# Altium schematic sheet 01-Lora-E5.SchDoc
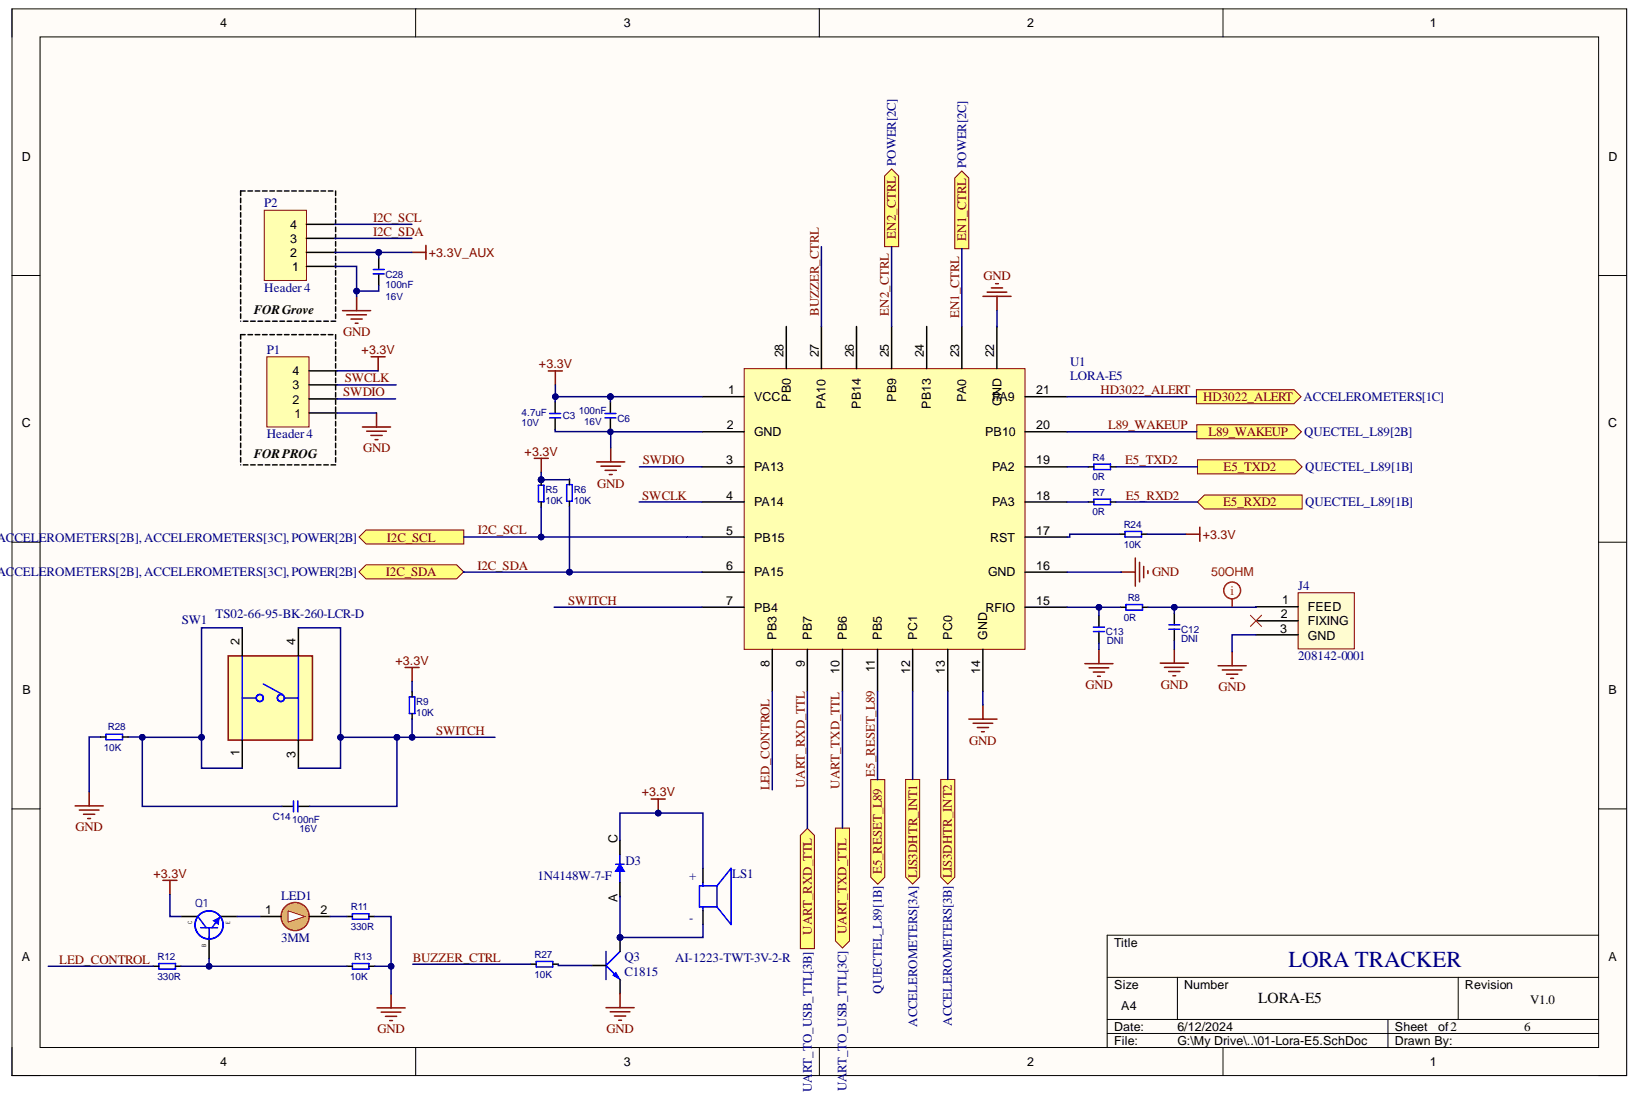
[diagram p0: 01-Lora-E5.SchDoc]

## Parts
C3  4.7uF  Rating: 10V  [T_CAPC0603X33N]
C6  100nF  Rating: 16V  [CAPC1005X60N]
C12  DNI  [CAPC1005X60N]
C13  DNI  [CAPC1005X60N]
C14  100nF  Rating: 16V  [CAPC1005X60N]
C28  100nF  Rating: 16V  [CAPC1005X60N]
D3  1N4148W-7-F  [SOD123]
J4  208142-0001  [2081420001]
LED1  3MM  [LED_GREEN_3MM]
LS1  AI-1223-TWT-3V-2-R  [XDCR_AI-1223-TWT-3V-2-R]
P1  Header 4  [HDR1X4]
P2  Header 4  [HDR1X4]
Q1  ''  [SOT95P240X120-3N]  (value not drawn)
Q3  C1815  [SOT23B]
R4  0R  [RESC1005X40N]
R5  10K  [RESC1005X40N]
R6  10K  [RESC1005X40N]
R7  0R  [RESC1005X40N]
R8  0R  [RESC1005X40N]
R9  10K  [RESC1005X40N]
R11  330R  [RESC1005X40N]
R12  330R  [RESC1005X40N]
R13  10K  [RESC1005X40N]
R24  10K  [RESC1005X40N]
R27  10K  [RESC1005X40N]
R28  10K  [RESC1005X40N]
SW1  TS02-66-95-BK-260-LCR-D  [SW_TS02-66-95-BK-260-LCR-D]
U1  LORA-E5  [LORA-E5]

## Ports
E5_RESET_L89, E5_RXD2, E5_TXD2, EN1_CTRL, EN2_CTRL, HD3022_ALERT, I2C_SCL, I2C_SDA, L89_WAKEUP, LIS3DHTR_INT1, LIS3DHTR_INT2, UART_RXD_TTL, UART_TXD_TTL

## Nets
+3.3V: C3.1 C6.1 D3.C LS1.P P1.4 Q1.3 R5.2 R6.2 R9.2 R24.1 U1.1(VCC)
+3.3V_AUX: C28.1 P2.2
GND: C3.2 C6.2 C12.2 C13.2 C28.2 J4.3 P1.1 P2.1 Q3.1 R11.2 R13.2 R28.1 U1.2(GND) U1.14(GND) U1.16(GND) U1.22(GND)
BUZZER_CTRL: R27.2 U1.27(PA10)
E5_RESET_L89: U1.11(PB5) ; port E5_RESET_L89
E5_RXD2: R7.1 ; port E5_RXD2
E5_TXD2: R4.1 ; port E5_TXD2
EN1_CTRL: U1.23(PA0) ; port EN1_CTRL
EN2_CTRL: U1.25(PB9) ; port EN2_CTRL
HD3022_ALERT: U1.21(PA9) ; port HD3022_ALERT
I2C_SCL: P2.4 R5.1 U1.5(PB15) ; port I2C_SCL
I2C_SDA: P2.3 R6.1 U1.6(PA15) ; port I2C_SDA
L89_WAKEUP: U1.20(PB10) ; port L89_WAKEUP
LED_CONTROL: R12.1 U1.8(PB3)
LIS3DHTR_INT1: U1.12(PC1) ; port LIS3DHTR_INT1
LIS3DHTR_INT2: U1.13(PC0) ; port LIS3DHTR_INT2
SWCLK: P1.3 U1.4(PA14)
SWDIO: P1.2 U1.3(PA13)
SWITCH: C14.1 R9.1 SW1.3 SW1.4 U1.7(PB4)
UART_RXD_TTL: U1.9(PB7) ; port UART_RXD_TTL
UART_TXD_TTL: U1.10(PB6) ; port UART_TXD_TTL
NetC12_1: C12.1 J4.1 R8.2
NetC13_1: C13.1 R8.1 U1.15(RFIO)
NetC14_2: C14.2 R28.2 SW1.1 SW1.2
NetD3_A: D3.A LS1.N Q3.2
NetLED1_1: LED1.1 Q1.2
NetLED1_2: LED1.2 R11.1
NetQ1_1: Q1.1 R12.2 R13.1
NetQ3_3: Q3.3 R27.1
NetR4_2: R4.2 U1.19(PA2)
NetR7_2: R7.2 U1.18(PA3)
NetR24_2: R24.2 U1.17(RST)

Not connected: J4 2; U1 24(PB13) 26(PB14) 28(PB0)

## Library data of the parts
C3 = C-X5R-4.7uF-10V-10%-0603: Capacitor Ceramic X5R 4.7uF 10V 10% 0603; Manufacturer Name: Generic; MP: CC0603KRX5R6BB475; Component Kind: Standard; Tolerance: 10%; Type: X5R; Value: 4.7uF; Library Name: Iris.SchLib
C6 C12-C14 C28 = C-X7R-100nF-16V-10%-0603: CAP CER 0.1UF 16V X7R 0402; MP: CC0402KRX7R7BB104; Tolerance: 10%; Component Kind: Standard; Type: X7R; Library Name: Evaluation Board.SchLib
  C6 C14 C28: Value: 100nF
  C12 C13: Value: DNI
D3 = 1N4148W-7-F: Diode Standard 100 V 300mA (DC) Surface Mount SOD-123; MF: Diodes Inc.; Availability: In Stock; Check_prices: https://www.snapeda.com/parts/1N4148W-7-F/Diodes+Inc./view-part/?ref=eda; Component Kind: Standard; Library Name: 1N4148W-7-F.SchLib; MP: 1N4148W-7-F; Package: SOD-123 Fairchild Semiconductor; Price: None; Purchase-URL: https://pricing.snapeda.com/search/part/1N4148W-7-F/?ref=eda; SnapEDA_Link: https://www.snapeda.com/parts/1N4148W-7-F/Diodes+Inc./view-part/?ref=snap
J4 = Component_1: Antennas ISM 868/915MHz Ceramic Antenna SMT; MF: MOLEX; Component Kind: Standard; Library Name: 208142-0001.SchLib; MP: 208142-0001
LED1 = LED 3mm: LED 3mm GREEN; MF: LUMEX; MP: SSL-LX30FT4GD; Component Kind: Standard; Library Name: My Library.SchLib
LS1 = AI-1223-TWT-3V-2-R: AUDIO INDICATOR 5VDC 30MA 85DB; MF: {'manufacturer': u'PUI Audio, Inc.'}; Availability: Unavailable; Component Kind: Standard; Library Name: AI-1223-TWT-3V-2-R.SchLib; MP: AI-1223-TWT-3V-2-R; Package: None; Price: None
P1 P2 = Header 4: Header, 4-Pin; MF: MOLEX; Component Kind: Standard; LatestRevisionDate: 17-Jul-2002; LatestRevisionNote: Re-released for DXP Platform.; Library Name: My Library.SchLib; MP: 22-28-4042; Signal Integrity: Connector; Publisher: Altium Limited
Q1 = MMBT3904LT1G: BJT NPN,40V,200MA,SOT23-3,SMD; MF: ON Semiconductor; No: 3; BJT Type: NPN; Component Kind: Standard; Database Table Name: BJT; Ic (Max): 200mA; Library Name: Component Library.DbLib; Mounting Type: Surface Mount (SMD); MP: MMBT3904LT1G; Package: SOT23-3 (SOT23, TO236AB, SOT346, SC59A, TO236AA, SMT3); Part Number: MMBT3904LT1G; Vce (Max): 40V
Q3 = C1815: NPN 600mW, ECB; MP: BC850C; Component Kind: Standard; Library Name: Cty1.SchLib; Value: C1815
R4 R7 R8 = R-22R-63mW-5%-0402: RES 0 OHM JUMPER 1/16W 0402; MP: RC0402JR-070RL; Rating: 63mW; Tolerance: 5%; Component Kind: Standard; Value: 0R; Library Name: Iris.SchLib
R5 R6 R9 R13 R24 R27 R28 = R-22R-63mW-5%-0402: Resistor 10K ohm 63mW 5% 0402; MP: RC0402JR-0710KL; Component Kind: Standard; Rating: 63mW; Tolerance: 5%; Value: 10K; Library Name: Iris.SchLib
R11 R12 = R-10KR-63mW-5%-0603: RES 330 OHM 5% 1/16W 0402; MP: RC0402JR-13330RL; Rating: 63mW; Component Kind: Standard; Tolerance: 5%; Value: 330R; Library Name: Evaluation Board.SchLib
SW1 = TS02-66-95-BK-260-LCR-D: Tactile Switches 6 x 6 mm, 9.5 mm Act Height, 260 gf, Black, Long Crimped, Through Hole, SPST,; MF: CUI Devices; Availability: Unavailable; Component Kind: Standard; Library Name: TS02-66-95-BK-260-LCR-D.IntLib; MP: TS02-66-95-BK-260-LCR-D; Package: None; Price: None
U1 = LORA-E5: Sub-GHz Modules Wio-E5 Wireless Module (Bulk)-28P; MP: 317990687; Component Kind: Standard; Library Name: LoRa_Asset_Tracker.SchLib
